# Altium schematic sheet PMIC.SchDoc
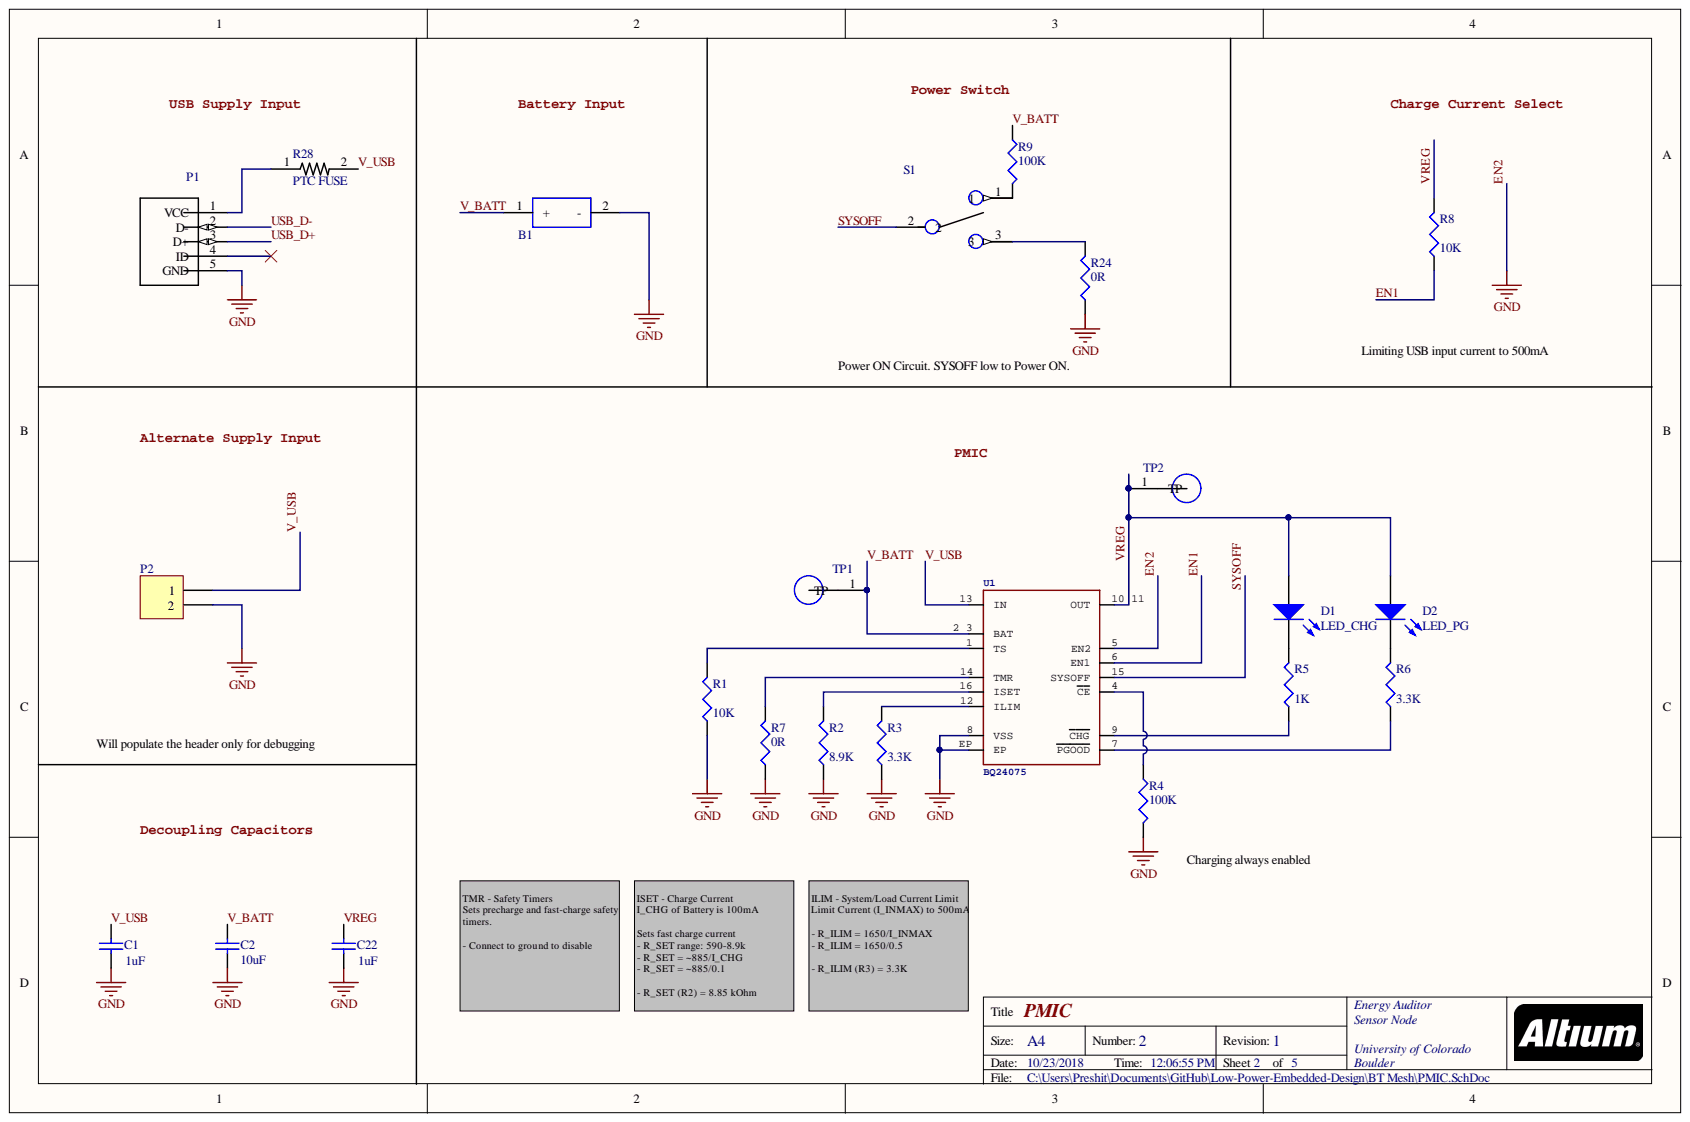
[diagram p0: PMIC.SchDoc]

## Parts
B1  SMTU2450N-LF_BULK  [SMTU2430-LF]  (value not drawn)
C1  1uF  [1608[0603]]
C2  10uF  [1608[0603]]
C22  1uF  [1608[0603]]
D1  LED_CHG  [3.2X1.6X1.1]
D2  LED_PG  [3.2X1.6X1.1]
P1  0475901001  [MOLEX_0475901001]  (value not drawn)
P2  Header 2  [HDR1X2]  (value not drawn)
R1  10K  [1608[0603]]
R2  8.9K  [1608[0603]]
R3  3.3K  [1608[0603]]
R4  100K  [1608[0603]]
R5  1K  [1608[0603]]
R6  3.3K  [1608[0603]]
R7  0R  [1608[0603]]
R8  10K  [1608[0603]]
R9  100K  [1608[0603]]
R24  0R  [1608[0603]]
R28  PTC FUSE  [RESC3216X125N]
S1  AYZ0102AGRLC  [SW_AYZ0102AGRLC]  (value not drawn)
TP1  5019  [TP380X203]  (value not drawn)
TP2  5019  [TP380X203]  (value not drawn)
U1  BQ24075  [QFN50P300X300X100-17N]

## Nets
EN1: R8.1 U1.6(EN1)
EN2: B1.2 C1.1 C2.1 C22.1 P1.5(GND) P2.2 R1.1 R2.1 R3.1 R4.2 R7.1 R24.1 U1.5(EN2) U1.8(VSS) U1.EP
SYSOFF: S1.2 U1.15(SYSOFF)
USB_D+: P1.3(D+)
USB_D-: P1.2(D-)
V_BATT: B1.1 C2.2 R9.1 TP1.1 U1.2 3(BAT)
V_USB: C1.2 P2.1 R28.2 U1.13(IN)
VREG: C22.2 D1.1 D2.1 R8.2 TP2.1 U1.10 11(OUT)
NetD1_2: D1.2 R5.2
NetD2_2: D2.2 R6.2
NetP1_1: P1.1(VCC) R28.1
NetR1_2: R1.2 U1.1(TS)
NetR2_2: R2.2 U1.16(ISET)
NetR3_2: R3.2 U1.12(ILIM)
NetR4_1: R4.1 U1.4(CE)
NetR5_1: R5.1 U1.9(CHG)
NetR6_1: R6.1 U1.7(PGOOD)
NetR7_2: R7.2 U1.14(TMR)
NetR9_2: R9.2 S1.1
NetR24_2: R24.2 S1.3

Not connected: P1 4(ID)

## Library data of the parts
B1 = SMTU2450N-LF_BULK: Coin Cell Battery Holders SFC MNT FOR CR2450N; Manufacturer: RENATA; Manufacturer Part Number: SMTU2450N-LF BULK; Component Kind: Standard; ComponentLink1Description: Mouser Link; ComponentLink1URL: https://www.mouser.com/ProductDetail/Renata/SMTU2450N-LF-BULK?qs=%2fha2pyFadujPRC6SfxjNC1eKhjF19ladzRXvix6tp%252bjYkhocq%252bWWcw%3d%3d; Library Name: SMTU2450N-LF_BULK.SchLib; Mouser Part Number: 614-SMTU2450N-LF
C1 C22 = Cap: CAP CER 1UF 16V X7R 0603; Manufacturer: Samsung Electro-Mechanics; Manufacturer Part Number: CL10B105MO8NNWC; Component Kind: Standard; ComponentLink1Description: Digi-Key Link; ComponentLink1URL: https://www.digikey.com/product-detail/en/samsung-electro-mechanics/CL10B105MO8NNWC/1276-6524-1-ND/5961383; Digi-Key Part Number: 1276-6524-1-ND; Library Name: Miscellaneous Devices.IntLib; Signal Integrity: Cap; Simulation: CAP; Value: 1uF
C2 = Cap Semi: CAP CER 10UF 10V X5R 0603; Manufacturer: Murata Electronics North America; Manufacturer Part Number: GRM188R61A106KE69D; Component Kind: Standard; ComponentLink1Description: Digi-Key Link; ComponentLink1URL: https://www.digikey.com/products/en?keywords=490-10474-1-ND; Digi-Key Part Number: 490-10474-1-ND; Library Name: Miscellaneous Devices.IntLib; Signal Integrity: Cap Semi; Simulation: CAP; Value: 10uF
D1 = LED2: Blue 470nm LED Indication - Discrete 2.85V 0603 (1608 Metric); Manufacturer: OSRAM Opto Semiconductors Inc.; Manufacturer Part Number: LB Q39G-L2OO-35-1; Component Kind: Standard; ComponentLink1Description: Digi-Key Link; ComponentLink1URL: https://www.digikey.com/product-detail/en/osram-opto-semiconductors-inc/LB-Q39G-L2OO-35-1/475-2816-1-ND/2176355; Digi-Key Part Number: 475-2816-1-ND; Library Name: Miscellaneous Devices.IntLib; Simulation: LED2
D2 = LED2: LED GREEN DIFFUSED 0603 SMD; Manufacturer: OSRAM Opto Semiconductors Inc.; Manufacturer Part Number: LG L29K-F2J1-24-Z; Component Kind: Standard; ComponentLink1Description: Digi-Key Link; ComponentLink1URL: https://www.digikey.com/product-detail/en/osram-opto-semiconductors-inc/LG-L29K-F2J1-24-Z/475-3118-1-ND/4899549; Digi-Key Part Number: 475-3118-1-ND; Library Name: Miscellaneous Devices.IntLib; Simulation: LED2
P1 = 0475901001: Conn Micro USB Type AB RCP 5 POS 0.65mm Solder RA SMD 5 Terminal 1 Port Embossed T/R; Manufacturer: Molex, LLC; Component Kind: Standard; ComponentLink1Description: Digi-Key Link; ComponentLink1URL: https://www.digikey.com/products/en/connectors-interconnects/usb-dvi-hdmi-connectors/312?k=0475901001&k=&pkeyword=0475901001&pv7=2&sf=0&quantity=&ColumnSort=0&page=1&pageSize=25; Digi-Key Part Number: WM14336CT-ND; Library Name: 0475901001.IntLib; Manufacturer Part Number: 0475901001
P2 = Header 2: Header, 2-Pin; Component Kind: Standard; Library Name: Miscellaneous Connectors.IntLib; Signal Integrity: Connector
R1 R8 = Res3: RES SMD 10K OHM 1% 1/8W 0603; Manufacturer: Vishay Beyschlag; Manufacturer Part Number: MCT06030C1002FP500; Component Kind: Standard; ComponentLink1Description: Digi-Key Link; ComponentLink1URL: https://www.digikey.com/products/en?keywords=MCT0603-10.0K-CFCT-ND; Digi-Key Part Number: MCT0603-10.0K-CFCT-ND; Library Name: Miscellaneous Devices.IntLib; Signal Integrity: Res; Simulation: RESISTOR; Value: 10K
R2 = Res3: RES SMD 8.87KOHM 0.5% 1/10W 0603; Manufacturer: Yageo; Manufacturer Part Number: RT0603DRD078K87L; Component Kind: Standard; ComponentLink1Description: Digi-Key Link; ComponentLink1URL: https://www.digikey.com/product-detail/en/yageo/RT0603DRD078K87L/311-8.87KDCT-ND/1035893; Digi-Key Part Number: 311-8.87KDCT-ND; Library Name: Miscellaneous Devices.IntLib; Signal Integrity: Res; Simulation: RESISTOR; Value: 8.9K
R3 R6 = Res3: RES SMD 3.3K OHM 5% 1/10W 0603; Manufacturer: Panasonic Electronic Components; Manufacturer Part Number: ERJ-3GEYJ332V; Component Kind: Standard; ComponentLink1Description: Digi-Key Link; ComponentLink1URL: https://www.digikey.com/product-detail/en/panasonic-electronic-components/ERJ-3GEYJ332V/P3.3KGCT-ND/135146; Digi-Key Part Number: P3.3KGCT-ND; Library Name: Miscellaneous Devices.IntLib; Signal Integrity: Res; Simulation: RESISTOR; Value: 3.3K
R4 R9 = Res3: RES SMD 100K OHM 0.1% 1/10W 0603; Manufacturer: Susumu; Manufacturer Part Number: RG1608P-104-B-T5; Component Kind: Standard; ComponentLink1Description: Digi-Key Link; ComponentLink1URL: https://www.digikey.com/products/en/resistors/chip-resistor-surface-mount/52?k=RG1608P-104-B-T5&k=&pkeyword=RG1608P-104-B-T5&pv7=2&sf=0&quantity=&ColumnSort=0&page=1&pageSize=25; Digi-Key Part Number: RG16P100KBCT-ND; Library Name: Miscellaneous Devices.IntLib; Signal Integrity: Res; Simulation: RESISTOR; Value: 100K
R5 = Res3: RES SMD 1K OHM 0.1% 1/10W 0603; Manufacturer: Yageo; Manufacturer Part Number: RT0603BRD071KL; Component Kind: Standard; ComponentLink1Description: Digi-Key Link; ComponentLink1URL: https://www.digikey.com/product-detail/en/yageo/RT0603BRD071KL/YAG1237CT-ND/4340590; Digi-Key Part Number: YAG1237CT-ND; Library Name: Miscellaneous Devices.IntLib; Signal Integrity: Res; Simulation: RESISTOR; Value: 1K
R7 R24 = Res3: RES 0 OHM JUMPER 1/8W 0603; Manufacturer: Vishay Beyschlag; Manufacturer Part Number: MCT0603MZ0000ZP500; Component Kind: Standard; ComponentLink1Description: Digi-Key Link; ComponentLink1URL: https://www.digikey.com/product-detail/en/vishay-beyschlag/MCT0603MZ0000ZP500/749-1745-1-ND/7348053; Digi-Key Part Number: 749-1745-1-ND; Library Name: Miscellaneous Devices.IntLib; Signal Integrity: Res; Simulation: RESISTOR; Value: 0R
R28 = 0ZCA0075FF2G: PTC RESET FUSE 6V 500MA 1206; Manufacturer: Bel Fuse; Manufacturer Part Number: Littelfuse Inc.; Component Kind: Standard; ComponentLink1Description: Digi-Key Link; ComponentLink1URL: https://www.digikey.com/product-detail/en/littelfuse-inc/1206L050YR/F2112CT-ND/455721; Digi-Key Part Number: F2112CT-ND; Library Name: PTC-1206.SchLib
S1 = AYZ0102AGRLC: SWITCH SLIDE SPDT 100MA 12V; Manufacturer: C&K; Component Kind: Standard; ComponentLink1Description: Digi-Key Link; ComponentLink1URL: https://www.digikey.com/products/en/switches/slide-switches/213?k=AYZ0102AGRLC&k=&pkeyword=AYZ0102AGRLC&pv7=2&sf=0&quantity=&ColumnSort=0&page=1&pageSize=25; Digi-Key Part Number: 401-2012-1-ND; Library Name: AYZ0102AGRLC.SchLib; Manufacturer Part Number: AYZ0102AGRLC
TP1 TP2 = 5019: Phosphor Bronze Contact Miniature Silver Plated Surface Mount Test Points; Manufacturer: Keystone; Component Kind: Standard; ComponentLink1Description: Digi-Key Link; ComponentLink1URL: https://www.digikey.com/product-detail/en/keystone-electronics/5019/36-5019CT-ND/3907344?utm_source=snapeda&utm_medium=aggregator&utm_campaign=symbol; Digi-Key Part Number: 36-5019CT-ND; Library Name: 5019.IntLib; Manufacturer Part Number: 5019
U1 = BQ24075: IC LI-ION CHARGE MGMT 16-QFN; Manufacturer: Texas Instruments; Manufacturer Part Number: BQ24075RGTR; Component Kind: Standard; ComponentLink1Description: Digi-Key Link; ComponentLink1URL: https://www.digikey.com/products/en/integrated-circuits-ics/pmic-battery-chargers/781?k=BQ24075RGTR&k=&pkeyword=BQ24075RGTR&pv7=2&sf=0&quantity=&ColumnSort=0&page=1&pageSize=25; Digi-Key Part Number: 296-38874-1-ND; Library Name: SparkFun-PowerIC.IntLib
